# Altium schematic sheet Smart_AC_Monitor.SchDoc(Smart_AC_Monitor)
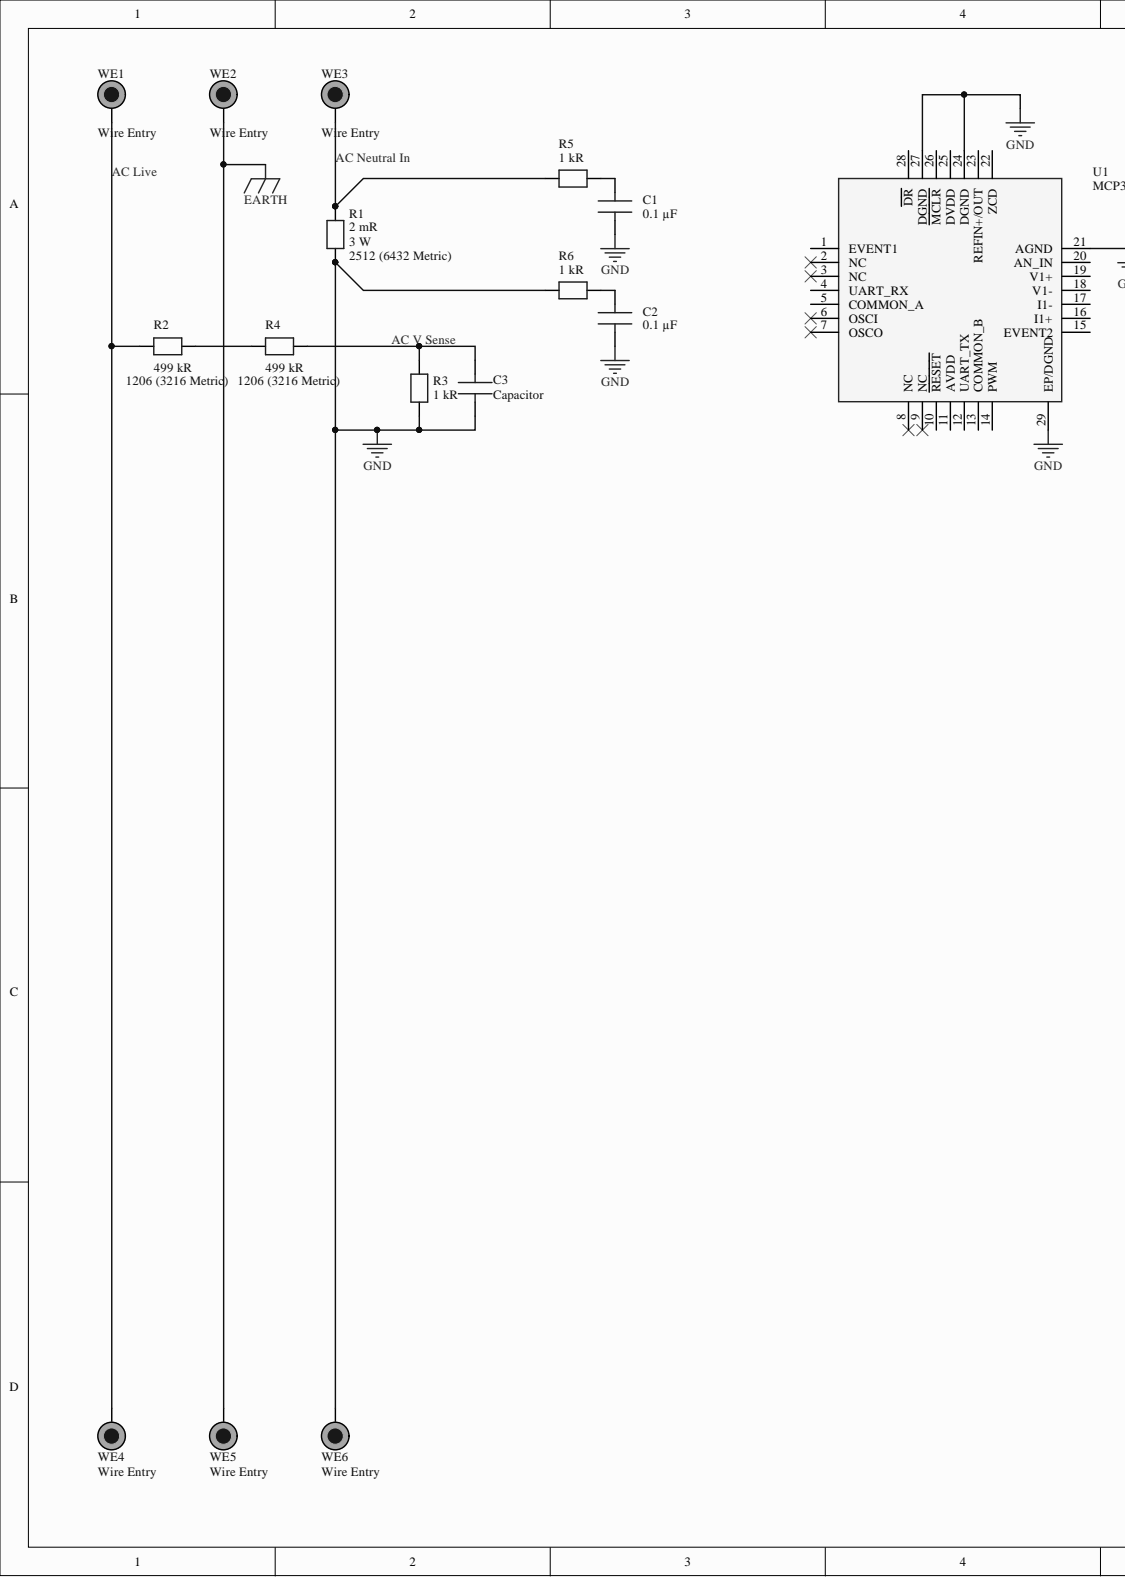
[diagram p0: Smart_AC_Monitor.SchDoc, left]
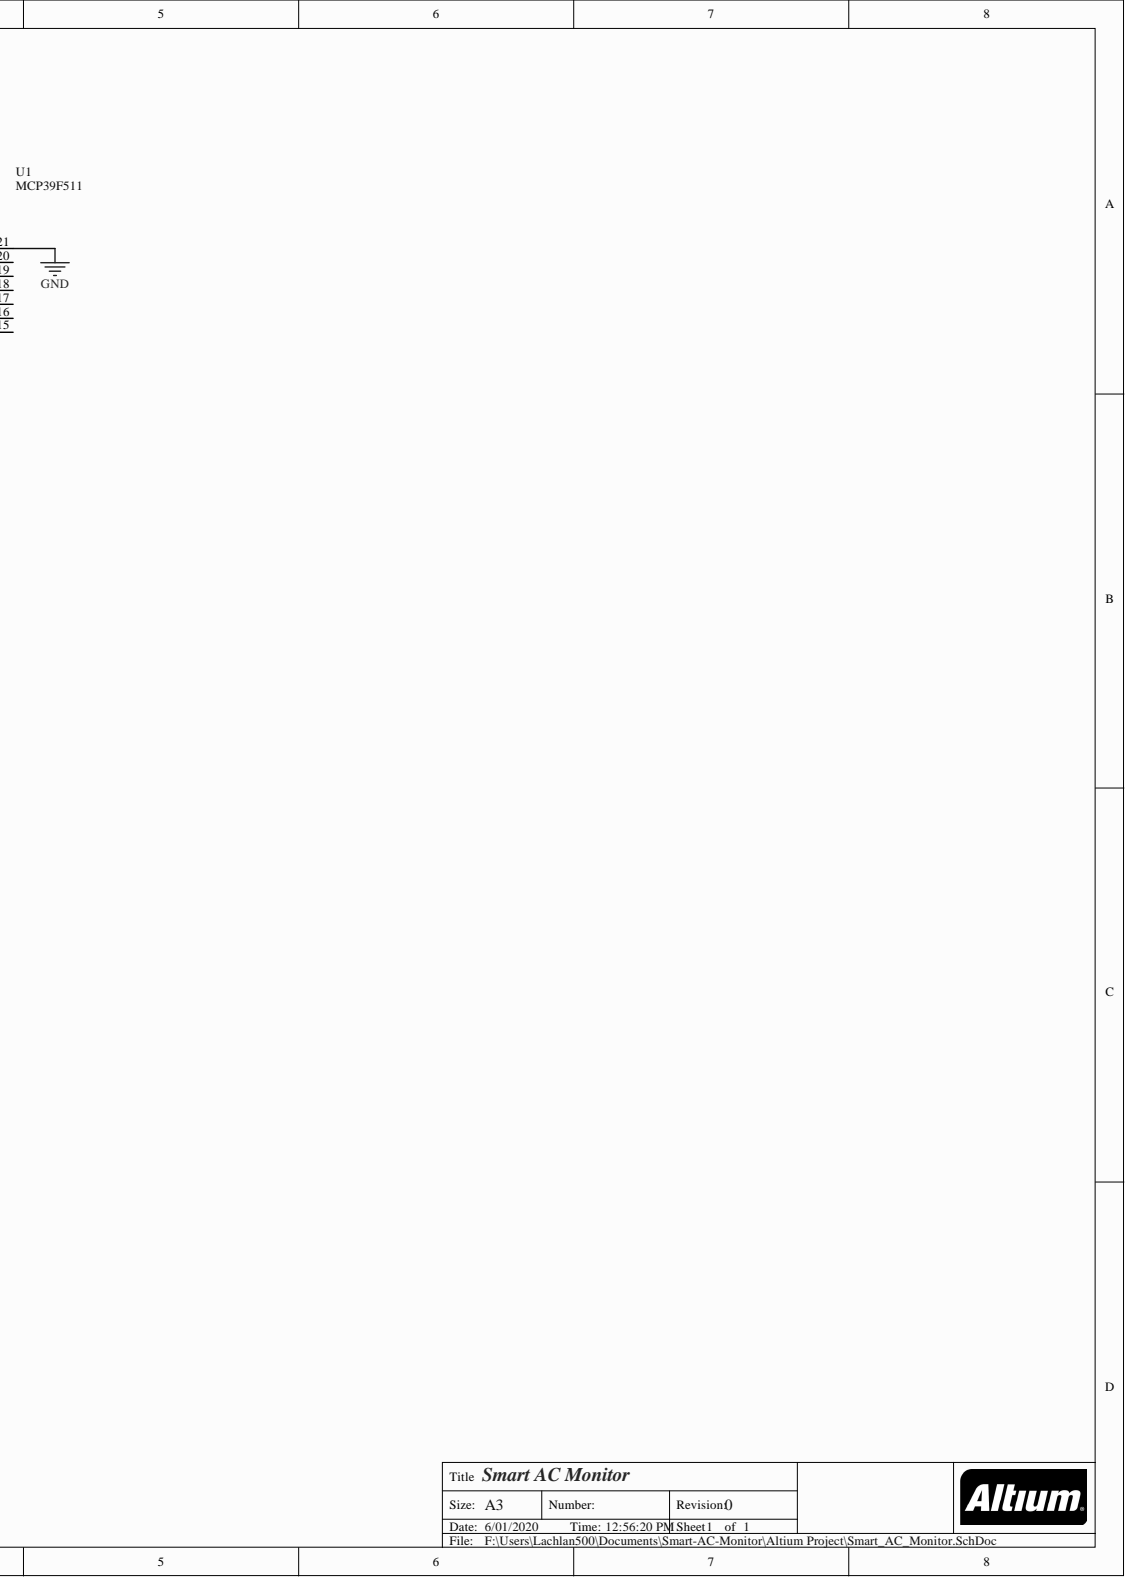
[diagram p1: Smart_AC_Monitor.SchDoc, right]

## Parts
C1  Capacitor  Capacitance: 0.1 µF  [0805]
C2  Capacitor  Capacitance: 0.1 µF  [0805]
C3  Capacitor  [0805]
R1  Resistance: 2 mR  Power: 3 W  [2512]  (hidden comment: Resistor)
R2  Resistance: 499 kR  Package: 1206 (3216 Metric)  [1206]  (hidden comment: Resistor)
R3  Resistance: 1 kR  [0805]  (hidden comment: Resistor)
R4  Resistance: 499 kR  Package: 1206 (3216 Metric)  [1206]  (hidden comment: Resistor)
R5  Resistance: 1 kR  [0805]  (hidden comment: Resistor)
R6  Resistance: 1 kR  [0805]  (hidden comment: Resistor)
U1  MCP39F511  [MCP39F511]
WE1  Wire Entry  [12AWGWireEntry]
WE2  Wire Entry  [12AWGWireEntry]
WE3  Wire Entry  [12AWGWireEntry]
WE4  Wire Entry  [12AWGWireEntry]
WE5  Wire Entry  [12AWGWireEntry]
WE6  Wire Entry  [12AWGWireEntry]

## Nets
EARTH: WE2.1 WE5.1
GND: C1.2 C2.2 C3.2 R1.2 R3.1 R6.1 U1.21(AGND) U1.24(DGND) U1.27(DGND) U1.29(EP/DGND) WE6.1
AC Live: R2.1 WE1.1 WE4.1
AC Neutral In: R1.1 R5.1 WE3.1
AC V Sense: C3.1 R3.2 R4.2
NetC1_1: C1.1 R5.2
NetC2_1: C2.1 R6.2
NetR2_2: R2.2 R4.1

Not connected: U1 1(EVENT1) 2(NC) 3(NC) 4(UART_RX) 5(COMMON_A) 6(OSCI) 7(OSCO) 8(NC) 9(NC) 10(RESET) 11(AVDD) 12(UART_TX) 13(COMMON_B) 14(PWM) 15(EVENT2) 16(I1+) 17(I1-) 18(V1-) 19(V1+) 20(AN_IN) 22(ZCD) 23(REFIN+/OUT) 25(DVDD) 26(MCLR) 28(DR)

## Library data of the parts
C1 C2 = Capacitor: AVX Corporation 08055D104KAT2A; Unique Part Number: LM_CAP_0001; Voltage: 50 V; Type (Ceramic, Aluminium, etc#): Ceramic X5R; Tolerance: ±10%; Database Table Name: Capacitors$; Package: 0805 (2012 Metric); Manufacturer Part Number: AVX Corporation 08055D104KAT2A; Library Name: Database.DbLib; Digikey Part Number: 478-12790-1-ND; Component Kind: Standard
C3 = Capacitor: AVX Corporation 08055D104KAT2A; Unique Part Number: LM_CAP_0001; Voltage: 50 V; Type (Ceramic, Aluminium, etc#): Ceramic X5R; Tolerance: ±10%; Database Table Name: Capacitors$; Capacitance: 0.1 µF; Package: 0805 (2012 Metric); Manufacturer Part Number: AVX Corporation 08055D104KAT2A; Library Name: Database.DbLib; Digikey Part Number: 478-12790-1-ND; Component Kind: Standard
R1 = 2 mR 2512 (6432 Metric) Chip: Unique Part Number: LM_RES_0002; Manufacturer Part Number: Riedon CSRL3-0R002F8; Tolerance: 0.01; Database Table Name: Resistors$; Type (Chip, Axial, etc#): Chip; Package: 2512 (6432 Metric); Library Name: Database.DbLib; Digikey Part Number: 696-1836-1-ND; Description: 2 mR 2512 (6432 Metric) Chip; Component Kind: Standard
R2 R4 = 499 kR 1206 (3216 Metric) Chip: Unique Part Number: LM_RES_0003; Manufacturer Part Number: Stackpole Electronics Inc RMCF1206FT499K; Tolerance: 0.01; Power: 0.25 W; Database Table Name: Resistors$; Type (Chip, Axial, etc#): Chip; Library Name: Database.DbLib; Digikey Part Number: RMCF1206FT499KCT-ND; Description: 499 kR 1206 (3216 Metric) Chip; Component Kind: Standard
R3 = Resistor: Unique Part Number: LM_RES_0001; Manufacturer Part Number: Stackpole Electronics Inc RMCF0805FT1K00; Type (Chip, Axial, etc#): Chip; Tolerance: 0.01; Power: 0.125 W; Database Table Name: Resistors$; Package: 0805 (2012 Metric); Library Name: Database.DbLib; Digikey Part Number: RMCF0805FT1K00CT-ND; Component Kind: Standard
R5 R6 = 1 kR 0805 (2012 Metric) Chip: Unique Part Number: LM_RES_0001; Manufacturer Part Number: Stackpole Electronics Inc RMCF0805FT1K00; Tolerance: 0.01; Power: 0.125 W; Database Table Name: Resistors$; Type (Chip, Axial, etc#): Chip; Package: 0805 (2012 Metric); Library Name: Database.DbLib; Digikey Part Number: RMCF0805FT1K00CT-ND; Description: 1 kR 0805 (2012 Metric) Chip; Component Kind: Standard
U1 = MCP39F511: Single Phase Meter IC 28-QFN (5x5); Unique Part Number: LM_IC_0001; Manufacturer Part Number: Microchip Technology MCP39F511-E/MQ; Database Table Name: ICs$; Temperature Range: -40°C ~ 125°C; Package: 28-QFN (5x5); Library Name: Database.DbLib; Digikey Part Number: MCP39F511-E/MQ-ND; Component Kind: Standard
WE1-WE6 = Wire Entry: 12 AWG Wire Entry; Unique Part Number: LM_OTH_0001; Database Table Name: Other$; Library Name: Database.DbLib; Component Kind: Standard
